# Altium schematic sheet DEBUG_CONSOLE.SchDoc(DEBUG_CONSOLE)
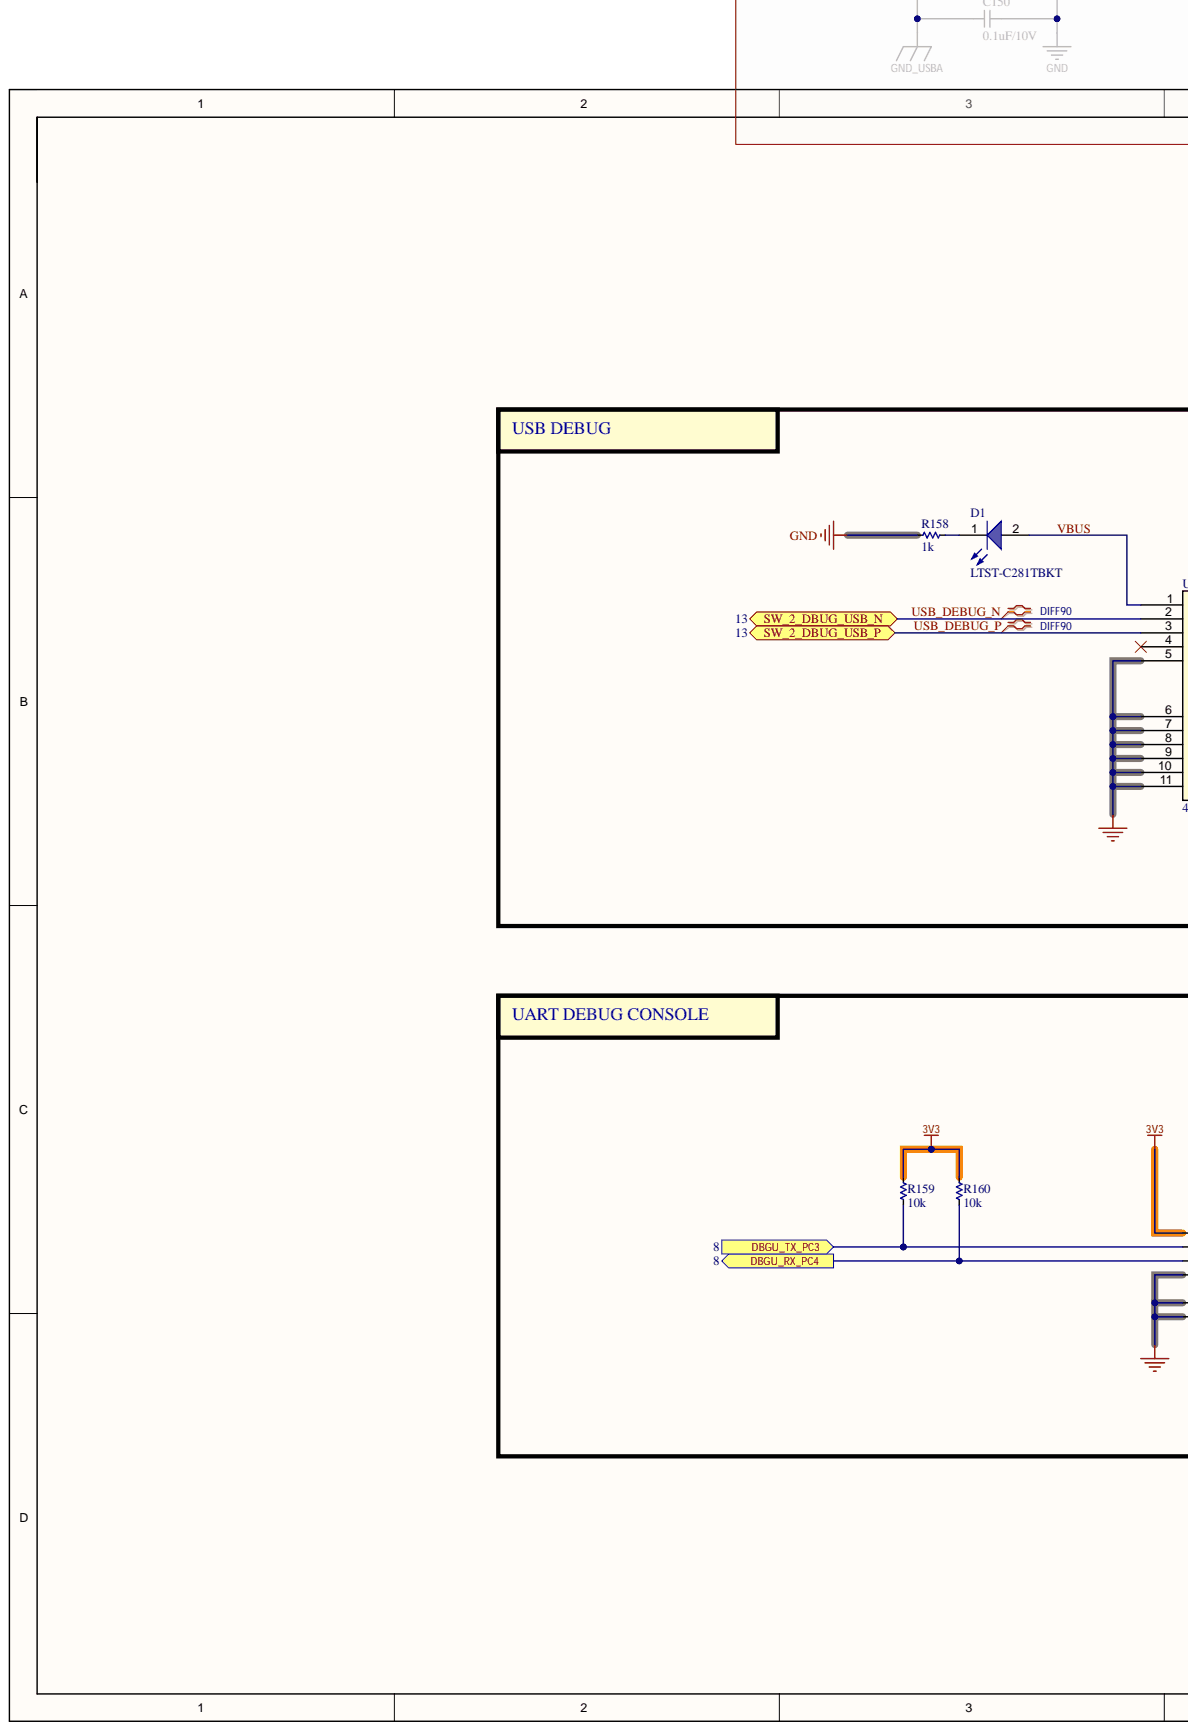
[diagram p0: DEBUG_CONSOLE.SchDoc, left]
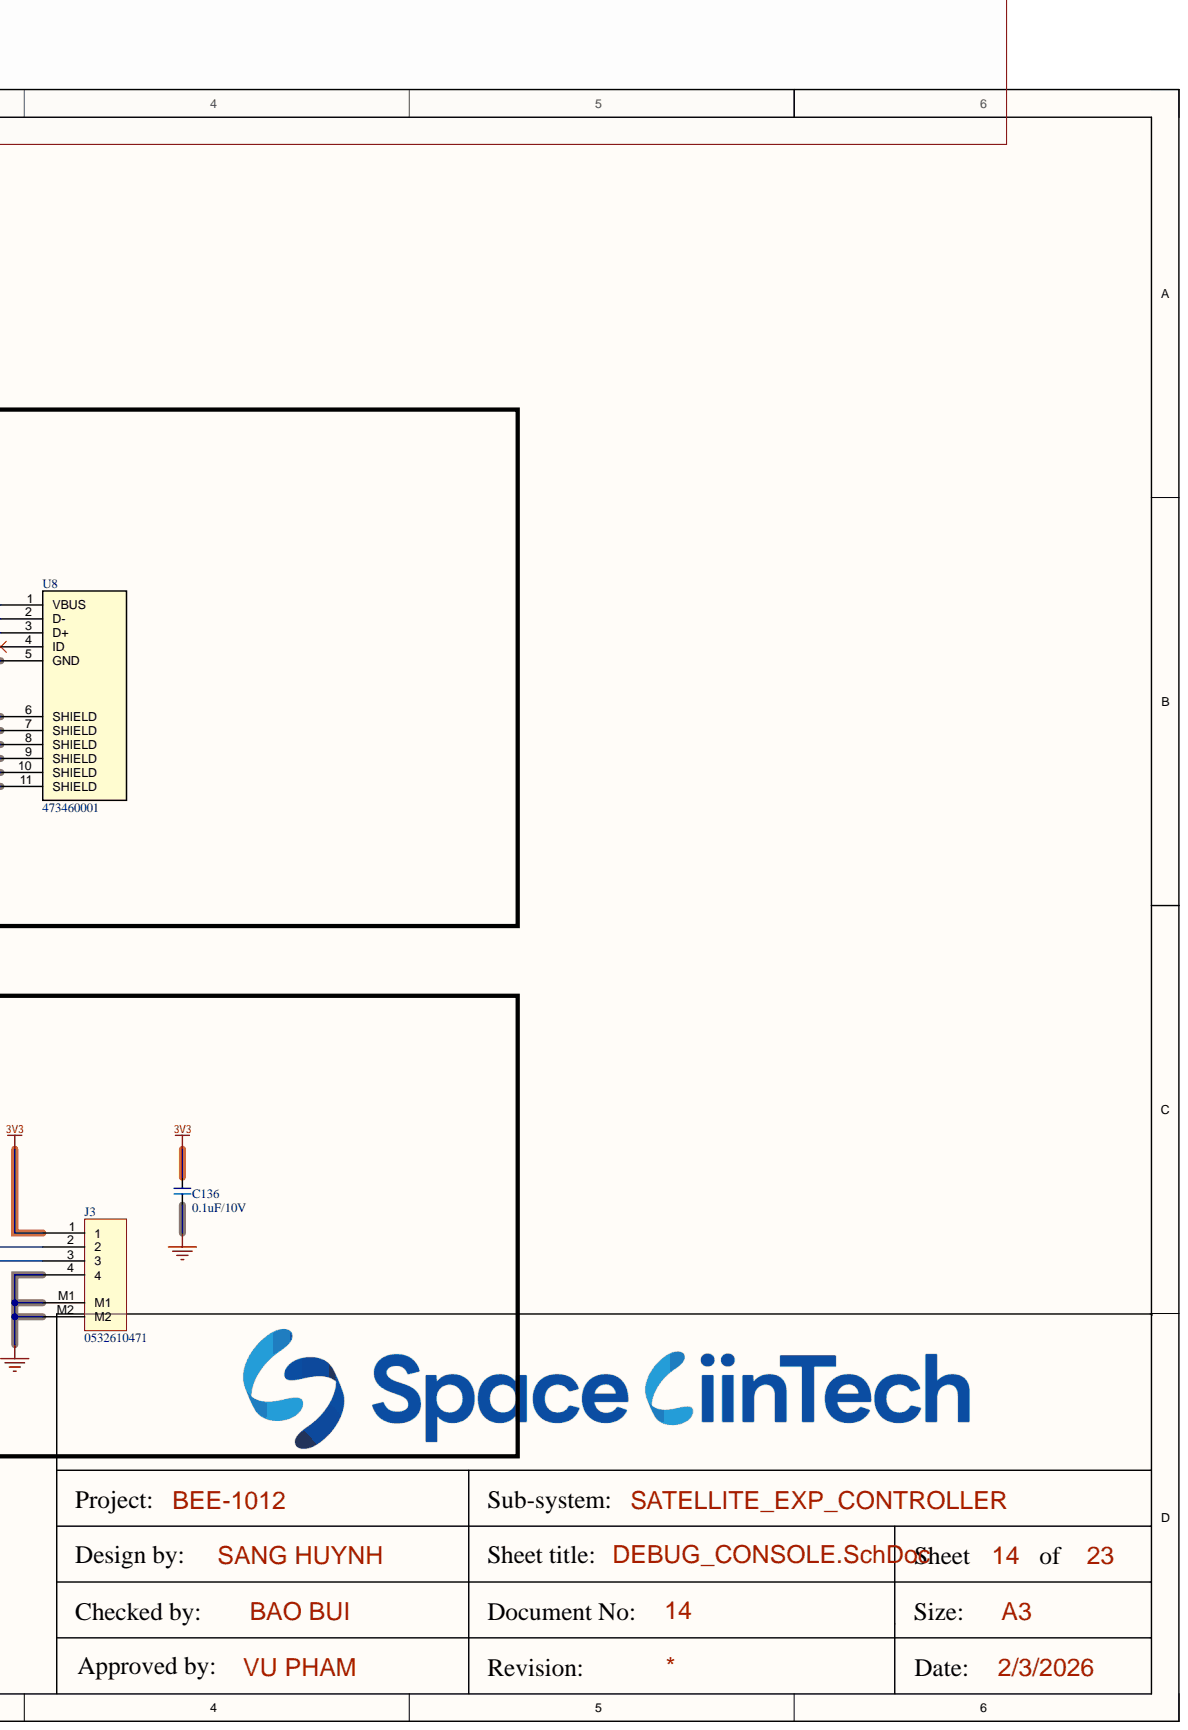
[diagram p1: DEBUG_CONSOLE.SchDoc, right]

## Parts
C136  0.1uF/10V  [CAP_C_1005X50_L]
D1  LTST-C281TBKT  [LED_0402]
J3  0532610471  [MOLEX_0532610471]
R158  1k  [RES_C_1005x30_L]
R159  10k  [RES_C_1005x30_L]
R160  10k  [RES_C_1005x30_L]
U8  473460001  [47346-0001_MOL]

## Ports
DBGU_RX_PC4, DBGU_TX_PC3, SW_2_DBUG_USB_N, SW_2_DBUG_USB_P

## Nets
3V3: C136.2 J3.1 R159.1 R160.1
GND: C136.1 J3.4 J3.M1 J3.M2 R158.1 U8.5(GND) U8.6(SHIELD) U8.7(SHIELD) U8.8(SHIELD) U8.9(SHIELD) U8.10(SHIELD) U8.11(SHIELD)
DBGU_RX_PC4: J3.3 R160.2 ; port DBGU_RX_PC4
DBGU_TX_PC3: J3.2 R159.2 ; port DBGU_TX_PC3
USB_DEBUG_N: U8.2(D-) ; port SW_2_DBUG_USB_N
USB_DEBUG_P: U8.3(D+) ; port SW_2_DBUG_USB_P
VBUS: D1.2 U8.1(VBUS)
NetD1_1: D1.1 R158.2

Not connected: U8 4(ID)

## Library data of the parts
C136 = _CAP_C_2: CAP CHIP; Component Kind: Standard; Library Name: IFBschelib.SchLib
D1 = LED_BLUE: Component Kind: Standard; Library Name: IFBschelib.SchLib
J3 = Header 4x1_2M: Component Kind: Standard; Library Name: IFBschelib.SchLib
R158-R160 = _RES_B_2: RES; Component Kind: Standard; Library Name: IFBschelib.SchLib
U8 = 473460001: Micro-USB B Receptacle with Flange, Bottom Mount, Surface Mount, Lead-Free; Manufacturer_Name: Molex Connector Corporation; Component Kind: Standard; Manufacturer_Part_Number: 473460001; RefDes: RefDes; Type: Type; Copyright: Copyright (C) 2025 Ultra Librarian. All rights reserved.; Library Name: IFBschelib.SchLib
